# Altium schematic sheet MISC.SchDoc(MISC)
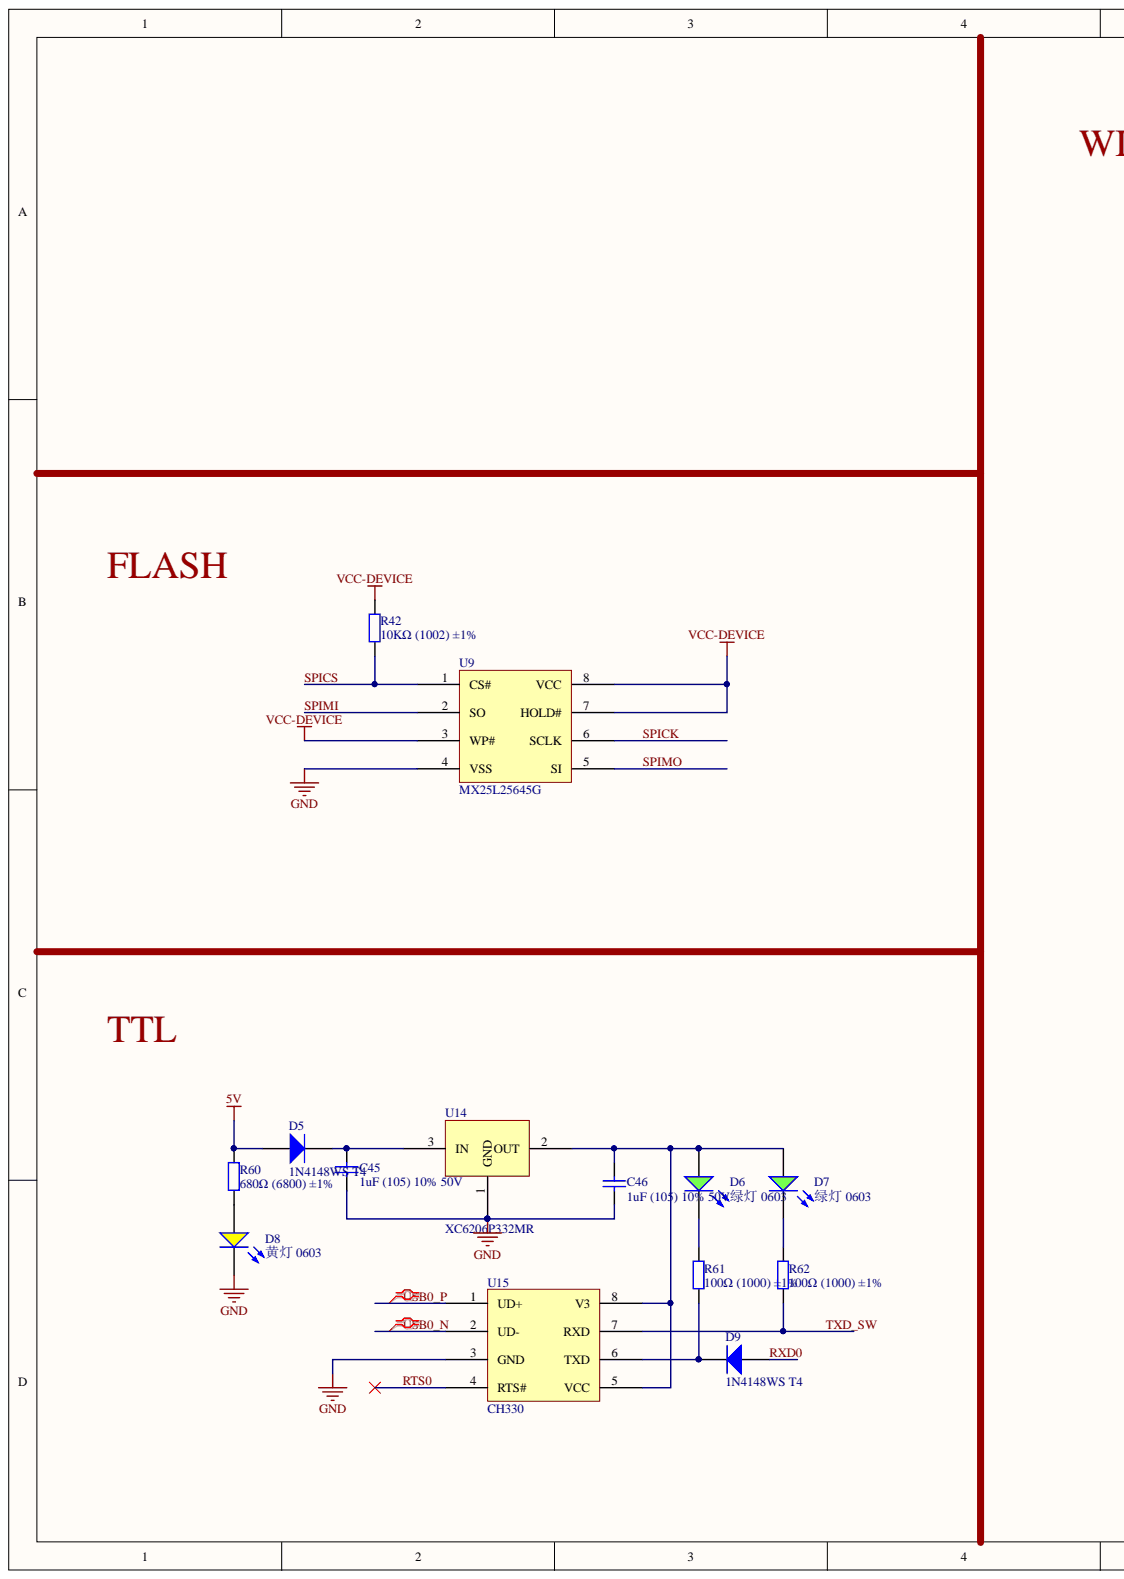
[diagram p0: MISC.SchDoc, left]
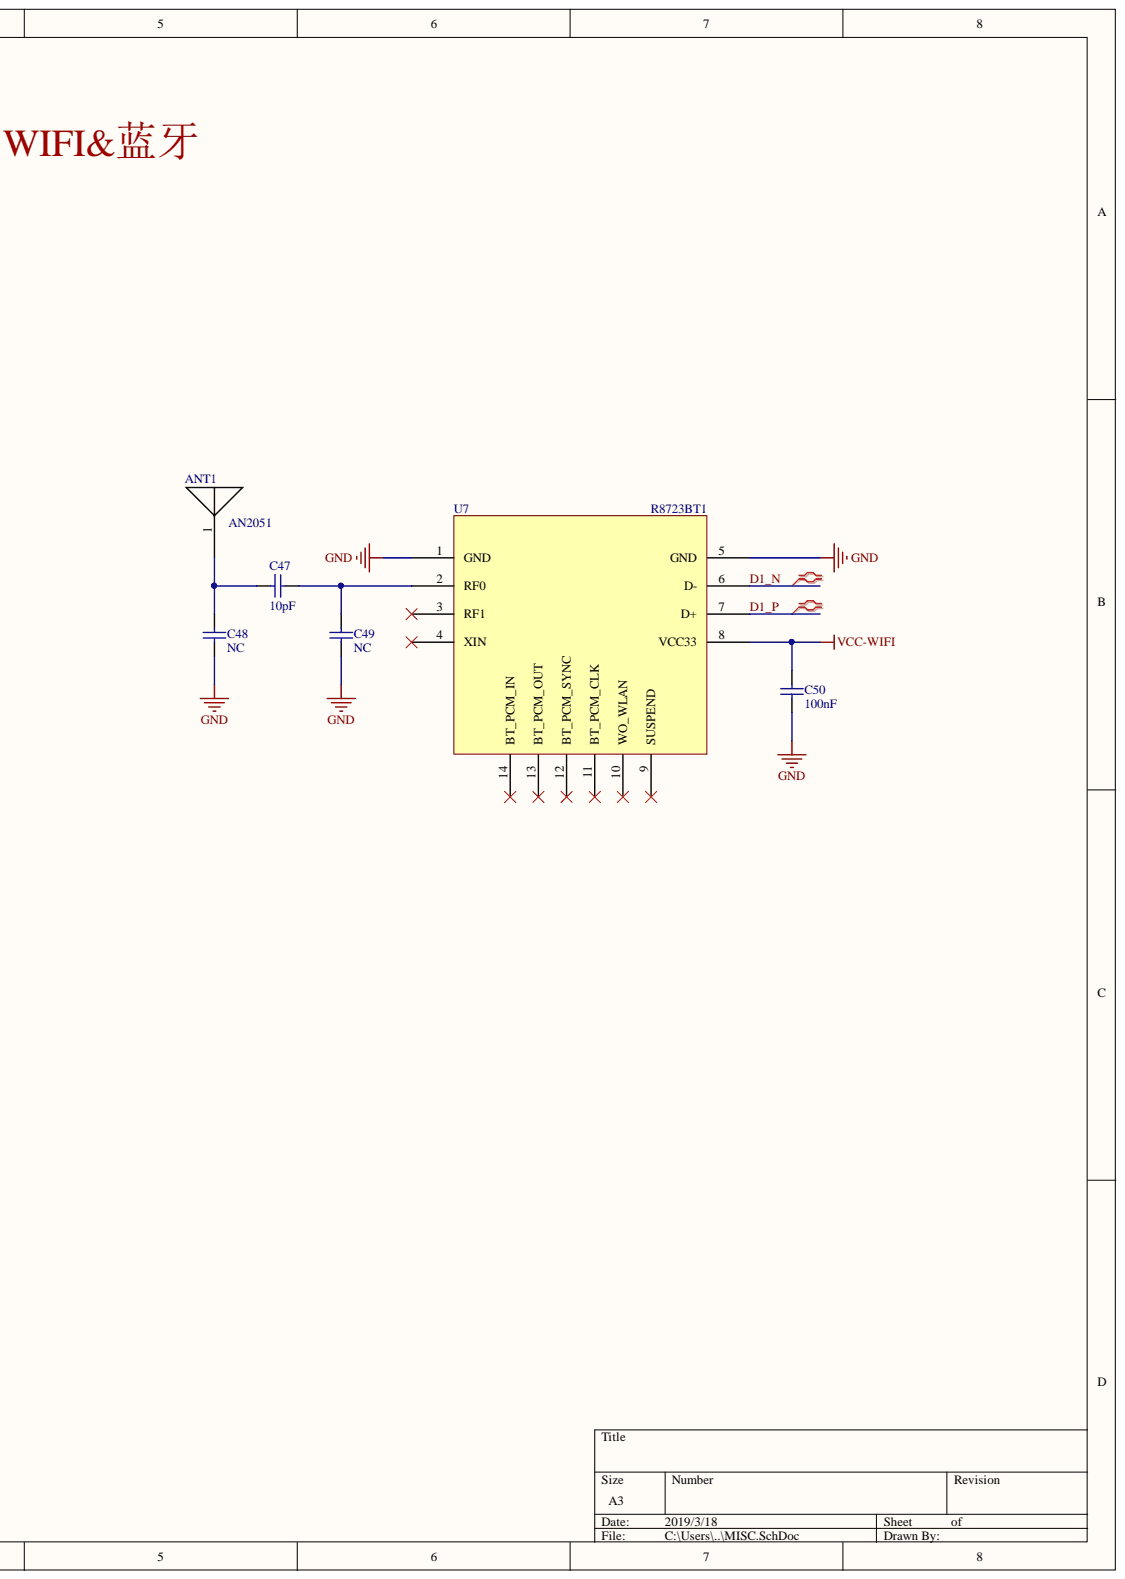
[diagram p1: MISC.SchDoc, right]

## Parts
ANT1  AN2051  [ANT_AN2051]
C45  1uF (105) 10% 50V  [0603_C]
C46  1uF (105) 10% 50V  [0603_C]
C47  10pF  [0402_C]
C48  NC  [0402_C]
C49  NC  [0402_C]
C50  100nF  [0402_C]
D5  1N4148WS T4  [SOD-323]
D6  绿灯 0603  [0603_LED_S1]
D7  绿灯 0603  Package: 0603  [0603_LED_S1]
D8  黄灯 0603  Package: 0603  [0603_LED_S1]
D9  1N4148WS T4  [SOD-323]
R42  10KΩ (1002) ±1%  [0402_R]
R60  680Ω (6800) ±1%  [0402_R]
R61  100Ω (1000) ±1%  [0402_R]
R62  100Ω (1000) ±1%  [0402_R]
U7  R8723BT1  [R8723BU_MOD]
U9  MX25L25645G  [SOP-8_208mil]
U14  XC6206P332MR  [SOT-23-3L]
U15  CH330  [SOP-8_150mil]

## Nets
5V: D5.1 R60.2
GND: C45.2 C46.2 C48.1 C49.1 C50.1 D8.K U7.1(GND) U7.5(GND) U9.4(VSS) U14.1 U15.3(GND)
VCC-DEVICE: R42.2 U9.3(WP#) U9.7(HOLD#) U9.8(VCC)
VCC-WIFI: C50.2 U7.8(VCC33)
D1_N: U7.6(D-)
D1_P: U7.7(D+)
RTS0: U15.4(RTS#)
RXD0: D9.1
SPICK: U9.6(SCLK)
SPICS: R42.1 U9.1(CS#)
SPIMI: U9.2(SO)
SPIMO: U9.5(SI)
TXD_SW: R62.2 U15.7(RXD)
USB0_N: U15.2(UD-)
USB0_P: U15.1(UD+)
NetANT1_1: ANT1.1 C47.1 C48.2
NetC45_1: C45.1 D5.2 U14.3
NetC46_1: C46.1 D6.A D7.A U14.2 U15.5(VCC) U15.8(V3)
NetC47_2: C47.2 C49.2 U7.2(RF0)
NetD6_K: D6.K R61.1
NetD7_K: D7.K R62.1
NetD8_A: D8.A R60.1
NetD9_2: D9.2 R61.2 U15.6(TXD)

Not connected: U7 3(RF1) 4(XIN) 9(SUSPEND) 10(WO_WLAN) 11(BT_PCM_CLK) 12(BT_PCM_SYNC) 13(BT_PCM_OUT) 14(BT_PCM_IN)

## Library data of the parts
ANT1 = ANT_AN2051: Multilayer Chip Antenna for  2.4GHz Wireless Communication; Component Kind: Standard; Library Name: Schlib_FFS.SchLib
C45 C46 = 0603 1uF (105) 10% 50V: 贴片电容; SuppliersPartNumber: C15849; Supplier: LC; Package: 0603; Library Name: Miscellaneous Devices LC.IntLib; ComponentLink1URL: http://www.szlcsc.com/product/details_16531.html; ComponentLink1Description: 供应商链接; Component Kind: Standard
C47-C49 = 0402 10pF (100) 5% 50V: 贴片电容; SuppliersPartNumber: C32949; Supplier: LC; Package: 0402; Library Name: Miscellaneous Devices LC.IntLib; ComponentLink1URL: http://www.szlcsc.com/product/details_33914.html; ComponentLink1Description: 供应商链接; Component Kind: Standard
C50 = 0402 100nF (104) 10% 16V: 贴片电容; SuppliersPartNumber: C1525; Supplier: LC; Package: 0402; Library Name: Miscellaneous Devices LC.IntLib; ComponentLink1URL: http://www.szlcsc.com/product/details_1877.html; ComponentLink1Description: 供应商链接; Component Kind: Standard
D5 D9 = SOD-323 1N4148WS T4: 二极管; SuppliersPartNumber: C2128; Supplier: LC; Package: SOD-323; Library Name: Miscellaneous Devices LC.IntLib; ComponentLink1URL: http://www.szlcsc.com/product/details_2485.html; ComponentLink1Description: 供应商链接; Component Kind: Standard
D6 D7 = 0603 绿灯: 发光二极管; SuppliersPartNumber: C72043; Supplier: LC; Library Name: Miscellaneous Devices LC.IntLib; ComponentLink1URL: http://www.szlcsc.com/product/details_73152.html; ComponentLink1Description: 供应商链接; Component Kind: Standard
  D6: Package: 0603
D8 = 0603 黄灯: 发光二极管; SuppliersPartNumber: C72038; Supplier: LC; Library Name: Miscellaneous Devices LC.IntLib; ComponentLink1URL: http://www.szlcsc.com/product/details_73147.html; ComponentLink1Description: 供应商链接; Component Kind: Standard
R42 = 0402 10KΩ (1002) 1%: 贴片电阻; SuppliersPartNumber: C25744; Supplier: LC; Package: 0402; Library Name: Miscellaneous Devices LC.IntLib; ComponentLink1URL: http://www.szlcsc.com/product/details_26487.html; ComponentLink1Description: 供应商链接; Component Kind: Standard
R60 = 0402 680Ω (6800) 1%: 贴片电阻; SuppliersPartNumber: C25130; Supplier: LC; Package: 0402; Library Name: Miscellaneous Devices LC.IntLib; ComponentLink1URL: http://www.szlcsc.com/product/details_25873.html; ComponentLink1Description: 供应商链接; Component Kind: Standard
R61 R62 = 0402 100Ω (1000) 1%: 贴片电阻; SuppliersPartNumber: C25076; Supplier: LC; Package: 0402; Library Name: Miscellaneous Devices LC.IntLib; ComponentLink1URL: http://www.szlcsc.com/product/details_25819.html; ComponentLink1Description: 供应商链接; Component Kind: Standard
U7 = BL-R8723BT1: EB-LINK R8723BT1 WiFi & BT Module; Library Name: V3SDV.SchLib; Component Kind: Standard
U9 = MX25L25645G: MX25L25645G 256Mbit SPI Flash; Component Kind: Standard; Library Name: Schlib_FFS.SchLib
U14 = XC6206: C5446 3.3V固定输出，Iout=200mA，Vin=6V(Max); Library Name: V3SDV.SchLib; Component Kind: Standard
U15 = CH330: SOP-8 150mil; Library Name: V3SDV.SchLib; Component Kind: Standard
